# Altium schematic sheet Do_an_I.SchDoc(Do_an_I)
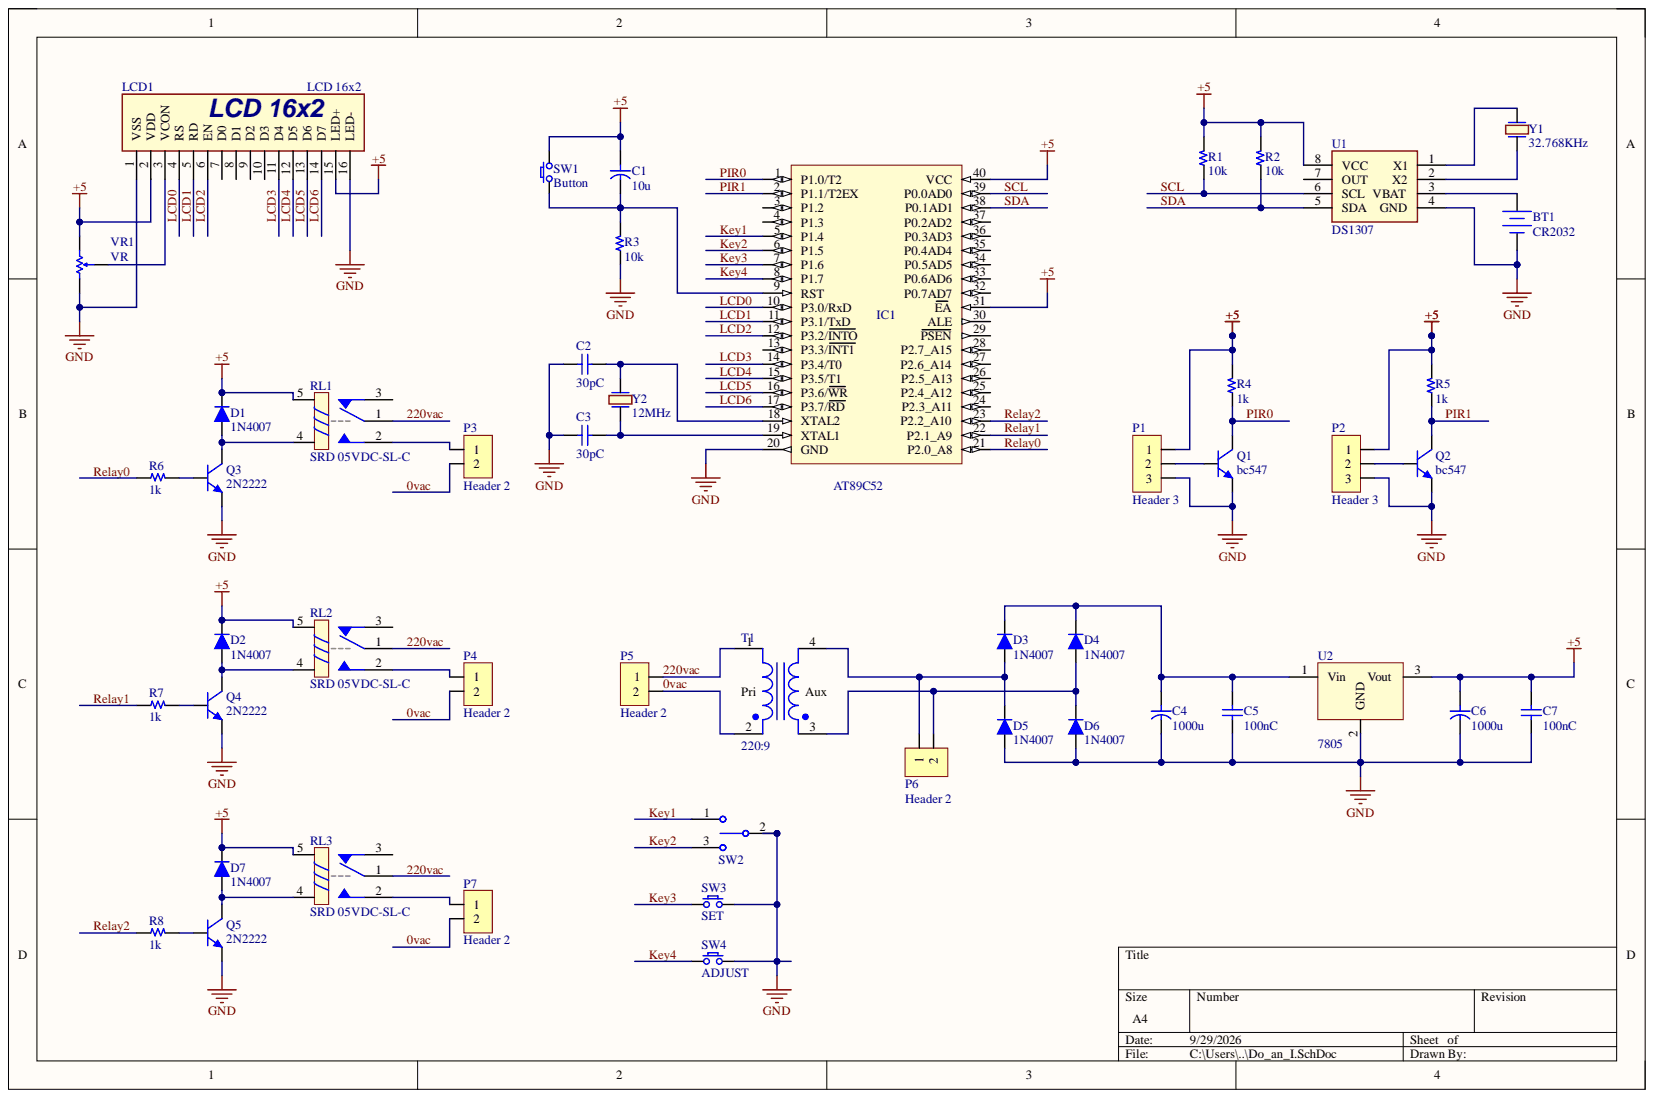
[diagram p0: Do_an_I.SchDoc]

## Parts
BT1  CR2032  [CON CR2032]
C1  10u  [CYL/D.200/LS.75]
C2  30pC  [CAP Ceramic/W300]
C3  30pC  [CAP Ceramic/W300]
C4  1000u  [CAP/D80/H125/LS35]
C5  100nC  [CAP Ceramic/W300]
C6  1000u  [CAP/D80/H125/LS35]
C7  100nC  [CAP Ceramic/W300]
D1  1N4007  [DO-35 (SOD27 )]
D2  1N4007  [DO-35 (SOD27 )]
D3  1N4007  [DO-35 (SOD27 )]
D4  1N4007  [DO-35 (SOD27 )]
D5  1N4007  [DO-35 (SOD27 )]
D6  1N4007  [DO-35 (SOD27 )]
D7  1N4007  [DO-35 (SOD27 )]
IC1  AT89C52  [*DIL40]
LCD1  LCD 16x2  [LCD 16x2]
P1  Header 3  [HDR/Header/3/BOX]
P2  Header 3  [HDR/Header/3/BOX]
P3  Header 2  [HDR/Terminal/2]
P4  Header 2  [HDR/Terminal/2]
P5  Header 2  [HDR/Terminal/2]
P6  Header 2  [HDR/Terminal/2]
P7  Header 2  [HDR/Terminal/2]
Q1  bc547  [TO-92/W100]
Q2  bc547  [TO-92/W100]
Q3  2N2222  [TO-92/W100]
Q4  2N2222  [TO-92/W100]
Q5  2N2222  [TO-92/W100]
R1  10k  [R 1/4W/300]
R2  10k  [R 1/4W/300]
R3  10k  [R 1/4W/300]
R4  1k  [R 1/4W/300]
R5  1k  [R 1/4W/300]
R6  1k  [R 1/4W/300]
R7  1k  [R 1/4W/300]
R8  1k  [R 1/4W/300]
RL1  SRD 05VDC-SL-C  [RL_SPDT_10A]
RL2  SRD 05VDC-SL-C  [RL_SPDT_10A]
RL3  SRD 05VDC-SL-C  [RL_SPDT_10A]
SW1  Button  [SW/2/DIP]
SW2  472123020311  [472123020311]  (value not drawn)
SW3  SET  [SW/2/DIP]
SW4  ADJUST  [SW/2/DIP]
T1  220:9
U1  DS1307  [DIP 8]
U2  7805  [TO-220]
VR1  VR  [R VAR D]
Y1  32.768KHz  [Crystal DIP-2]
Y2  12MHz  [Crystal HC49S DIP]

## Nets
+5: C1.1 C6.1 C7.2 D1.2 D2.2 D7.2 IC1.31(EA) IC1.40(VCC) LCD1.2(VDD) LCD1.15(LED+) P1.1 P2.1 R1.2 R2.2 R4.2 R5.2 RL1.5 RL2.5 RL3.5 SW1.2 U1.8(VCC) U2.3 VR1.1
GND: BT1.2 C2.1 C3.1 C4.2 C5.1 C6.2 C7.1 D5.1 D6.1 IC1.20(GND) LCD1.1(VSS) LCD1.16(LED-) P1.3 P2.3 Q1.2 Q2.2 Q3.2 Q4.2 Q5.2 R3.1 SW2.2 SW3.2 SW4.2 U1.4(GND) U2.2 VR1.3
0vac: P3.2 P4.2 P5.2 P7.2 T1.2
220vac: P5.1 RL1.1 RL2.1 RL3.1 T1.1
Key1: IC1.5(P1.4) SW2.1
Key2: IC1.6(P1.5) SW2.3
Key3: IC1.7(P1.6) SW3.1
Key4: IC1.8(P1.7) SW4.1
LCD0: IC1.10(P3.0/RxD) LCD1.4(RS)
LCD1: IC1.11(P3.1/TxD) LCD1.5(RD)
LCD2: IC1.12(P3.2/INTO) LCD1.6(EN)
LCD3: IC1.14(P3.4/T0) LCD1.11(D4)
LCD4: IC1.15(P3.5/T1) LCD1.12(D5)
LCD5: IC1.16(P3.6/WR) LCD1.13(D6)
LCD6: IC1.17(P3.7/RD) LCD1.14(D7)
PIR0: IC1.1(P1.0/T2) Q1.3 R4.1
PIR1: IC1.2(P1.1/T2EX) Q2.3 R5.1
Relay0: IC1.21(P2.0_A8) R6.1
Relay1: IC1.22(P2.1_A9) R7.1
Relay2: IC1.23(P2.2_A10) R8.1
SCL: IC1.39(P0.0AD0) R1.1 U1.6(SCL)
SDA: IC1.38(P0.1AD1) R2.1 U1.5(SDA)
NetBT1_1: BT1.1 U1.3(VBAT)
NetC1_2: C1.2 IC1.9(RST) R3.2 SW1.1
NetC2_2: C2.2 IC1.18(XTAL2) Y2.2
NetC3_2: C3.2 IC1.19(XTAL1) Y2.1
NetC4_1: C4.1 C5.2 D3.2 D4.2 U2.1
NetD1_1: D1.1 Q3.3 RL1.4
NetD2_1: D2.1 Q4.3 RL2.4
NetD3_1: D3.1 D5.2 P6.1 T1.4
NetD4_1: D4.1 D6.2 P6.2 T1.3
NetD7_1: D7.1 Q5.3 RL3.4
NetLCD1_3: LCD1.3(VCON) VR1.2
NetP1_2: P1.2 Q1.1
NetP2_2: P2.2 Q2.1
NetP3_1: P3.1 RL1.2
NetP4_1: P4.1 RL2.2
NetP7_1: P7.1 RL3.2
NetQ3_1: Q3.1 R6.2
NetQ4_1: Q4.1 R7.2
NetQ5_1: Q5.1 R8.2
NetU1_1: U1.1(X1) Y1.2
NetU1_2: U1.2(X2) Y1.1

Not connected: IC1 3(P1.2) 4(P1.3) 13(P3.3/INT1) 24(P2.3_A11) 25(P2.4_A12) 26(P2.5_A13) 27(P2.6_A14) 28(P2.7_A15) 29(PSEN) 30(ALE) 32(P0.7AD7) 33(P0.6AD6) 34(P0.5AD5) 35(P0.4AD4) 36(P0.3AD3) 37(P0.2AD2); LCD1 7(D0) 8(D1) 9(D2) 10(D3); RL1 3; RL2 3; RL3 3; U1 7(OUT)

## Library data of the parts
BT1 = Battery: Component Kind: Standard; Library Name: chipfc_altium_libs.IntLib
C1 C4 C6 = Capacitor: Capacitor pol; Component Kind: Standard; Library Name: chipfc_altium_libs.IntLib
C2 C3 C5 C7 = Capacitor NP: Capacitor non-pol; Component Kind: Standard; Library Name: chipfc_altium_libs.IntLib
D1-D7 = Diode: Default Diode; Component Kind: Standard; Library Name: chipfc_altium_libs.IntLib
IC1 = AT89C*52: 8051 series Microcontroller IC 8-Bit 16MHz 8KB (8K x 8) FLASH 44-PLCC (16.6x16.6); MF: Microchip; Check_prices: https://www.snapeda.com/parts/AT89C52/Microchip/view-part/?ref=eda; Component Kind: Standard; Library Name: AT89C_52.IntLib; MP: AT89C52; Package: TQFP-44 Microchip; SnapEDA_Link: https://www.snapeda.com/parts/AT89C52/Microchip/view-part/?ref=snap
LCD1 = LCD 16x2: Character LCD 16x2; Component Kind: Standard; Library Name: chipfc_altium_libs.IntLib
P1 P2 = Header 3: Header, 3-Pin; Component Kind: Standard; Library Name: chipfc_altium_libs.IntLib
P3-P7 = Header 2: Header, 2-Pin; Component Kind: Standard; Library Name: chipfc_altium_libs.IntLib
Q1-Q5 = Transistor NPN: NPN Bipolar Transistor; Component Kind: Standard; Library Name: chipfc_altium_libs.IntLib
R1-R8 = Resistor: Component Kind: Standard; Description: Resistor; Library Name: chipfc_altium_libs.IntLib
RL1-RL3 = Relay SPDT: Component Kind: Standard; Description: Relay SPDT; Library Name: chipfc_altium_libs.IntLib
SW1 SW3 SW4 = SW SPST: Tact switch 2 pin; Component Kind: Standard; Library Name: chipfc_altium_libs.IntLib
SW2 = 472123020311: WS-TOTV Ø 6.35mm Panel Cut-Out Vertical Operation Toggle Switch, SPDT, ON-OFF-ON, 30V, 1A; Manufacturer: Wurth Elektronik; Actuator Length: 7.5mm; Bushing Length: 7.1mm; Insulation Resistance: 1000MOhm; Withstanding Voltage: 500VAC; Category: Toggle Switches; Circuit: SPDT; Component Kind: Standard; ComponentLink1Description: Website Link; ComponentLink1URL: https://www.we-online.com/redexpert/article/472123020311?ad; ComponentLink2Description: Datasheet Link; ComponentLink2URL: https://www.we-online.com/redexpert/spec/472123020311?ad; Height: 23.4mm; Length: 12.7mm; Library Name: Altium_WS-TOTV_rev23b.IntLib; Manufacturer Part Number: 472123020311; Match Code: WS-TOTV; Mount: Through Hole; Operating Temperature Max: 85°C; Operating Temperature Min: -40°C; Packaging: Tray; Rated Current: 1A; Rated Voltage (DC): 30V; Switching Function: ON-OFF-ON; Width: 6.6mm
T1 = Trans 4-PIN: Transformer 4-PIN; Component Kind: Standard; Library Name: chipfc_altium_libs.IntLib
U2 = 78xx: LDO Fixed Voltage regulator; Component Kind: Standard; Library Name: chipfc_altium_libs.IntLib
VR1 = Resistor Variable: Component Kind: Standard; Description: Resistor Variable; Library Name: chipfc_altium_libs.IntLib
Y1 Y2 = Crystal: Component Kind: Standard; Library Name: chipfc_altium_libs.IntLib
U1 = DS1307: Component Kind: Standard; Library Name: chipfc_altium_libs.IntLib
